# Altium schematic sheet Sheet1.SchDoc(Sheet1)
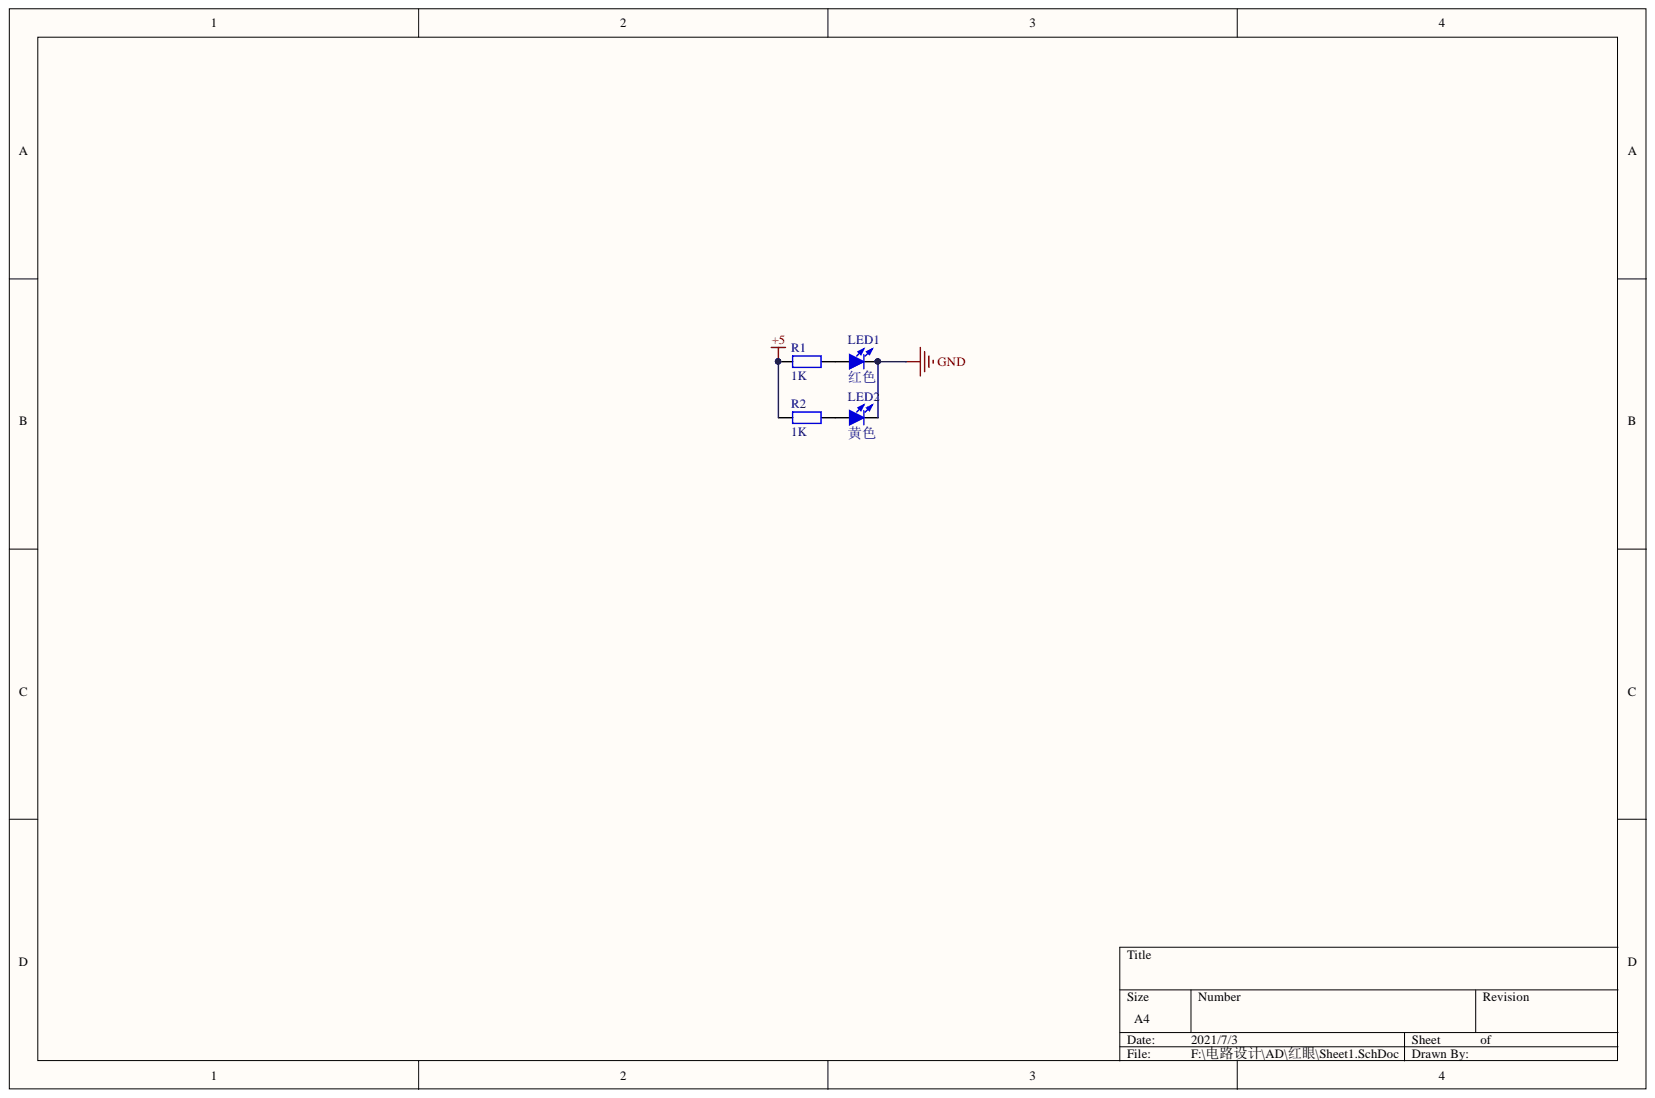
[diagram p0: Sheet1.SchDoc]

## Parts
LED1  红色  [LED 0805G]
LED2  黄色  [LED 0805G]
R1  1K  [R 0805_L]
R2  1K  [R 0805_L]

## Nets
+5: R1.2 R2.2
GND: LED1.2 LED2.2
NetLED1_1: LED1.1 R1.1
NetLED2_1: LED2.1 R2.1

## Library data of the parts
LED1 LED2 = LED-SMD: 贴片LED; 版权归属: 源创客; BOM名称: 贴片LED; Component Kind: Standard; Library Name: Library1.IntLib; 学习资源: www.ooocke.com
R1 R2 = R: 贴片电阻; 版权归属: 源创客; BOM名称: 贴片电阻; Component Kind: Standard; Library Name: Library1.IntLib; 学习资源: www.ooocke.com
